# Altium schematic sheet SIM800C_Board.SchDoc
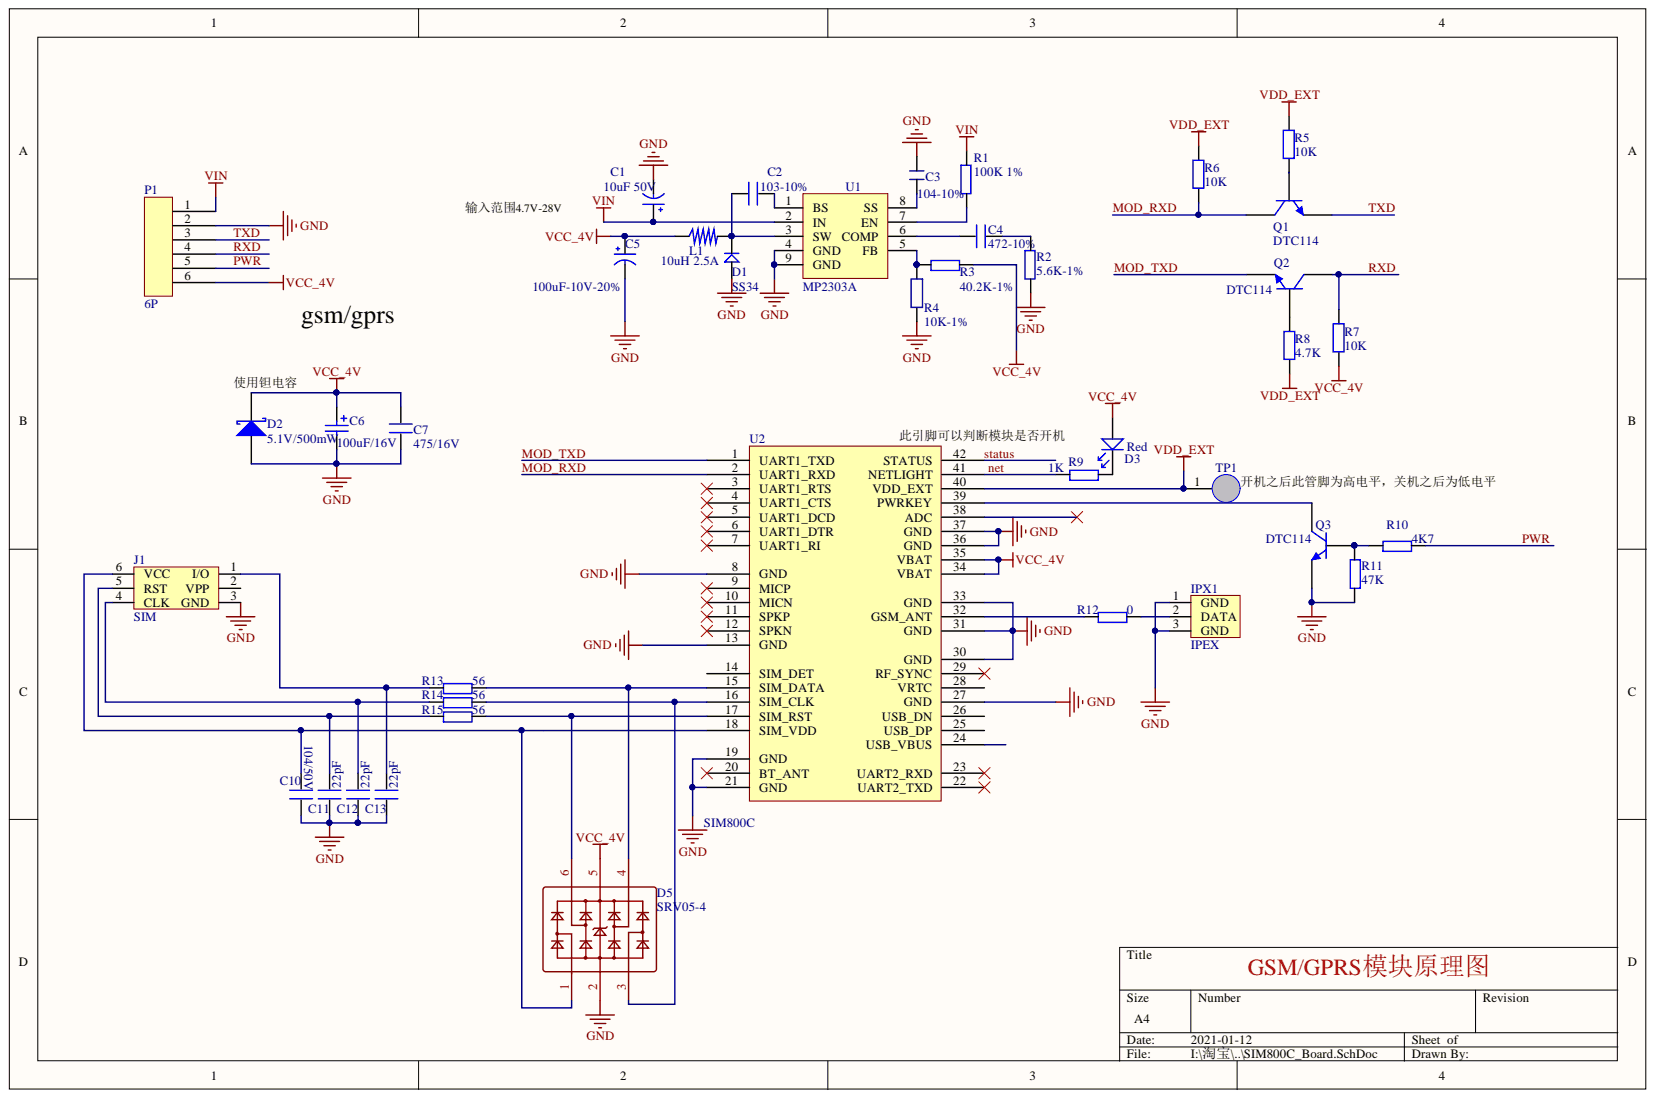
[diagram p0: SIM800C_Board.SchDoc]

## Parts
C1  10uF 50V  [C1206]
C2  103-10%  [C0603]
C3  104-10%  [C0603]
C4  472-10%  [C0603]
C5  100uF-10V-20%  [C1206]
C6  100uF/16V  [CASE-C_6032]
C7  475/16V  [C0603]
C10  104/50V  [C0603]
C11  22pF  [C0603]
C12  22pF  [C0603]
C13  22pF  [C0603]
D1  SS34  [SMA(DO-214AC)]
D2  5.1V/500mW  [SOD-123FL]
D3  Red  [G0603]
D5  SRV05-4
IPX1  IPEX  [IPEX]
J1  SIM  [MICRO_SIM_PUSH]
L1  10uH 2.5A  [6028]
P1  6P  [6H]
Q1  DTC114  [SOT-323]
Q2  DTC114  [SOT-323]
Q3  DTC114  [SOT-323]
R1  100K 1%  [0603]
R2  5.6K-1%  [0603]
R3  40.2K-1%  [0603]
R4  10K-1%  [0603]
R5  10K  [0603R]
R6  10K  [0603R]
R7  10K  [0603R]
R8  4.7K  [0603R]
R9  1K  [0603]
R10  4K7  [0603]
R11  47K  [0603]
R12  0  [0603]
R13  56  [0603]
R14  56  [0603]
R15  56  [0603]
TP1  Test  [Test]  (value not drawn)
U1  MP2303A  [SOIC-8]
U2  SIM800C  [sim800c]

## Nets
GND: C1.2 C3.2 C5.2 C6.2 C7.1 C10.2 C11.2 C12.2 C13.2 D1.1 D2.1 D5.2 IPX1.1 IPX1.3 J1.3(GND) P1.2 Q3.2 R2.2 R4.1 R11.1 U1.4(GND) U1.9(GND) U2.8(GND) U2.13(GND) U2.19(GND) U2.21(GND) U2.27(GND) U2.30(GND) U2.31(GND) U2.33(GND) U2.36(GND) U2.37(GND)
VCC_4V: C5.1 C6.1 C7.2 D2.2 D3.1 D5.5 L1.1 P1.6 R3.2 R7.2 U2.34(VBAT) U2.35(VBAT)
VDD_EXT: R5.1 R6.2 R8.1 TP1.1 U2.40(VDD_EXT)
VIN: C1.1 P1.1 R1.1 U1.2(IN)
MOD_RXD: Q1.3 R6.1 U2.2(UART1_RXD)
MOD_TXD: Q2.2 U2.1(UART1_TXD)
NET: R9.2 U2.41(NETLIGHT)
PWR: P1.5 R10.2
RXD: P1.4 Q2.3 R7.1
STATUS: U2.42(STATUS)
TXD: P1.3 Q1.2
NetC2_1: C2.1 D1.2 L1.2 U1.3(SW)
NetC2_2: C2.2 U1.1(BS)
NetC3_1: C3.1 U1.8(SS)
NetC4_1: C4.1 U1.6(COMP)
NetC4_2: C4.2 R2.1
NetC10_1: C10.1 D5.1 J1.6(VCC) U2.18(SIM_VDD)
NetC11_1: C11.1 J1.5(RST) R15.1
NetC12_1: C12.1 J1.4(CLK) R14.1
NetC13_1: C13.1 J1.1(I/O) R13.1
NetD3_2: D3.2 R9.1
NetD5_3: D5.3 R14.2 U2.16(SIM_CLK)
NetD5_4: D5.4 R13.2 U2.15(SIM_DATA)
NetD5_6: D5.6 R15.2 U2.17(SIM_RST)
NetIPX1_2: IPX1.2 R12.2
NetQ1_1: Q1.1 R5.2
NetQ2_1: Q2.1 R8.2
NetQ3_1: Q3.1 R10.1 R11.2
NetQ3_3: Q3.3 U2.39(PWRKEY)
NetR1_2: R1.2 U1.7(EN)
NetR3_1: R3.1 R4.2 U1.5(FB)
NetR12_1: R12.1 U2.32(GSM_ANT)

Not connected: J1 2(VPP); U2 3(UART1_RTS) 4(UART1_CTS) 5(UART1_DCD) 6(UART1_DTR) 7(UART1_RI) 9(MICP) 10(MICN) 11(SPKP) 12(SPKN) 14(SIM_DET) 20(BT_ANT) 22(UART2_TXD) 23(UART2_RXD) 24(USB_VBUS) 25(USB_DP) 26(USB_DN) 28(VRTC) 29(RF_SYNC) 38(ADC)

## Library data of the parts
C1 = CAP: 三星 CL32B106KBJNFNE; Component Kind: Standard; Library Name: ATK-SIM900A.IntLib
C2 = C: 风华 0603B103K500NT; Component Kind: Standard; Library Name: ATK-SIM900A.IntLib
C3 = 104: 三星 CL10B104KC8NNNC; Component Kind: Standard
C4 = C: 风华 0603B472K500NT; Component Kind: Standard; Library Name: ATK-SIM900A.IntLib
C5 = CAP: AVX TAJB107M010RNJ; Component Kind: Standard; Library Name: ATK-SIM900A.IntLib
C6 = Cap Pol: Component Kind: Standard; Library Name: 电容.SchLib; Value: 100uF/16V
C7 C10-C13 = C: Component Kind: Standard; Library Name: GPS_NEO-6M.SCHLIB
  C7: Value: 475/16V
  C10: Value: 104/50V
  C11-C13: Value: 22pF
D1 = D: Component Kind: Standard; Description: SS34; Library Name: ATK-SIM900A.IntLib
D2 = Diode BAT17: Silicon RF Schottky Diode for Mixer Applications in the VHF/UHF Range; Note: Pin 2 is not connected and not drawn.; Component Kind: Standard; ComponentLink1Description: Manufacturer Link; ComponentLink1URL: http://www.infineon.com/; ComponentLink2Description: Datasheet; ComponentLink2URL: http://www.infineon.com/upload/Document/cmc_upload/documents/009/363/bat17series.pdf; DatasheetVersion: Oct-1999; LatestRevisionDate: 29-May-2009; LatestRevisionNote: IPC-7351 Footprint Added.; Library Name: Miscellaneous Devices.IntLib; PackageDescription: 3-Pin SOT-23 Package 0.95 mm Pitch, 2.5 mm Lead Span; PackageReference: SOT-23; PackageVersion: Sep-2001; Price: 0.5; Published: 21-Mar-2003; Simulation: D188; Value: 5.1V/500mW; Publisher: Altium Limited
D3 = LED: Component Kind: Standard; Library Name: GPS_NEO-6M.SCHLIB; Value: Red
IPX1 = IPEX: IPEX座; Component Kind: Standard; Library Name: GPS_NEO-6M.SCHLIB; Value: IPEX
J1 = SIM: 博信微电子元件专营 小卡6P MICRO SIM 6P自弹 手机SIM卡座 卡座 自弹 PUSH SIM小卡; Component Kind: Standard; Library Name: ATK-SIM900A.IntLib
L1 = L_SOP: 顺络 SWPA6028S100MT; Component Kind: Standard; Library Name: ATK-SIM900A.IntLib
Q1-Q3 = triode NPN: Component Kind: Standard; Value: DTC114; Library Name: 晶体管.SchLib
R1 = R: 厚声 0603WAF4702T5E; Component Kind: Standard; Library Name: ATK-SIM900A.IntLib
R2 = R: 厚声 0603WAF5601T5E; Component Kind: Standard; Library Name: ATK-SIM900A.IntLib
R3 = R: 厚声 0603WAF4022T5E; Component Kind: Standard; Library Name: ATK-SIM900A.IntLib
R4 = Res: 厚声0603WAF1002T5E; Component Kind: Standard
R5-R8 = Res2: Resistor; Value: 1K; Component Kind: Standard; LatestRevisionDate: 17-Jul-2002; LatestRevisionNote: Re-released for DXP Platform.; PackageReference: AXIAL-0.4; Published: 8-Jun-2000; Library Name: KONG_BASIC_COMPONENT.SchLib; Publisher: Altium Limited
R9-R15 = R: Component Kind: Standard; Library Name: GPS_NEO-6M.SCHLIB
  R9: Value: 1K
  R10: Value: 4K7
  R11: Value: 47K
  R12: Value: 0
  R13-R15: Value: 56
U1 = MP2303A: MPS MP2303ADN-LF-Z; Component Kind: Standard; Library Name: TK_DTU_V0100.SchLib
D5 = SRV05-4: Component Kind: Standard; Library Name: 晶体管.SchLib
P1 = 6P: Component Kind: Standard; Library Name: 各种接插件.SchLib
TP1 = Test: Component Kind: Standard; Library Name: 其他.SchLib
U2 = SIM800C: Component Kind: Standard; Library Name: IC.SchLib; value: SIM800C
